# Altium schematic sheet USBDM_SWD_SER_JS16CWJ.SchDoc(USBDM_SWD_SER_JS16CWJ)
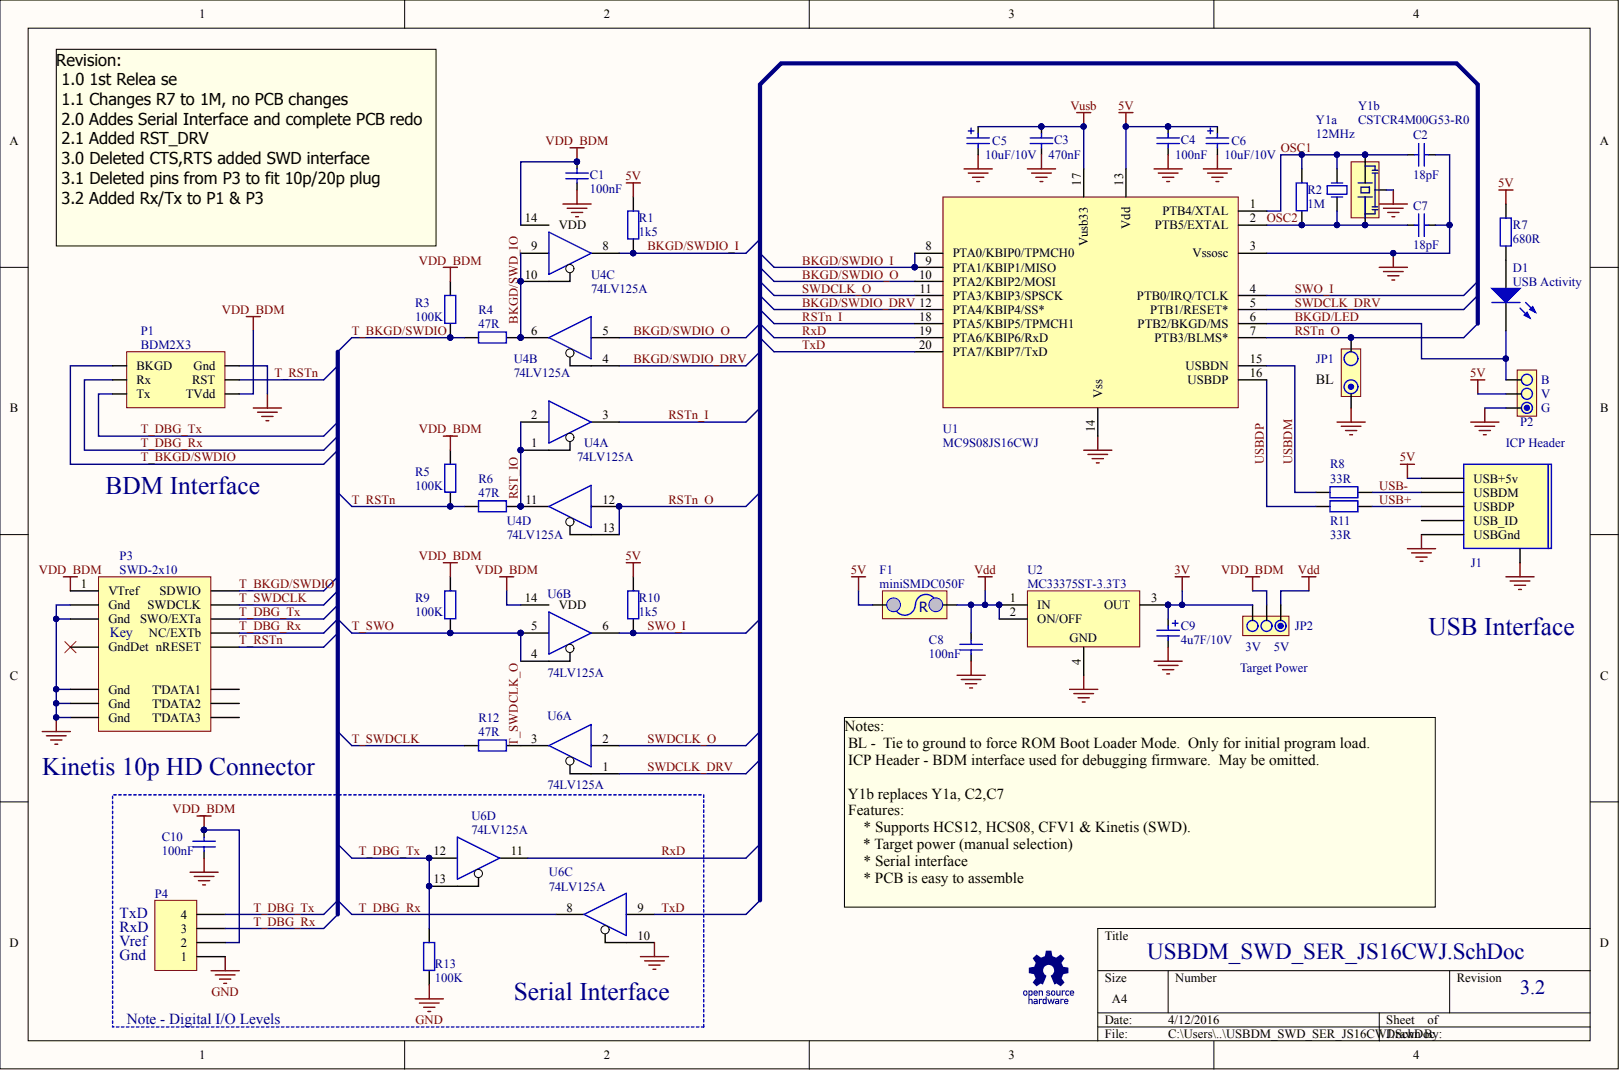
[diagram p0: USBDM_SWD_SER_JS16CWJ.SchDoc]

## Parts
C1  100nF  [CCNP2012-0805]
C2  18pF  [CCNP2012-0805]
C3  470nF  [CCNP2012-0805]
C4  100nF  [CCNP2012-0805]
C5  10uF/10V  [TC3528-1411]
C6  10uF/10V  [TC3528-1411]
C7  18pF  [CCNP2012-0805]
C8  100nF  [CCNP2012-0805]
C9  4u7F/10V  [SMT_CaseA]
C10  100nF  [CCNP2012-0805]
D1  LED Green  [LED-SMT-2]  (value not drawn)
F1  miniSMDC050F  [CR4532-1812]
J1  USB_MINI_CONNECTOR  [USB_Mini - SMT2]  (value not drawn)
JP1  PIN2X1  [HDR1X2a]  (value not drawn)
JP2  PIN3X1  [PIN1X3]  (value not drawn)  (no BOM)
Logo  OSHW Logo  [OSHW]  (value not drawn)  (no BOM)
P1  BDM2X3  [BDM]
P2  PIN3X1  [PIN1X3]  (value not drawn)  (no BOM)
P3  SWD-2x10  [SWD-2x10 - reduced]
P4  Serial  [HDR1X4]  (value not drawn)
R1  1k5  [CR2012-0805]
R2  1M  [CR2012-0805]
R3  100K  [CR2012-0805]
R4  47R  [CR2012-0805]
R5  100K  [CR2012-0805]
R6  47R  [CR2012-0805]
R7  680R  [CR2012-0805]
R8  33R  [CR2012-0805]
R9  100K  [CR2012-0805]
R10  1k5  [CR2012-0805]
R11  33R  [CR2012-0805]
R12  47R  [CR2012-0805]
R13  100K  [CR2012-0805]
U1  MC9S08JS16CWJ  [SO-G20]
U2  MC33375ST-3.3T3  [318E-04]
U4  74LV125A  [751A-03_N]  (parts A,B,C,D)
U6  74LV125A  [751A-03_N]  (parts A,B,C,D)
Y1a  12MHz  [XTAL_SMT - 5x3.2]
Y1b  CSTCR4M00G53-R0  [Resonator_Murato]

## Nets
3V: C9.1 JP2.3 U2.3(OUT)
5V: C4.2 C6.1 F1.1 J1.VBUS(USB+5v) P2.2 R1.2 R7.2 R10.2 U1.13(Vdd)
GND: C1.1 C2.1 C3.1 C4.1 C5.2 C6.2 C7.1 C8.1 C9.2 C10.1 J1.0 J1.G(USBGnd) J1.Shield JP1.1 P1.2(Gnd) P2.1 P3.3(Gnd) P3.5(Gnd) P3.15(Gnd) P3.17(Gnd) P3.19(Gnd) P4.1 R13.1 U1.3(Vssosc) U1.14(Vss) U2.4 U4.7 U6.7 U6.10 Y1b.2
VDD: C8.2 F1.2 JP2.1 U2.1(IN) U2.2(ON/OFF)
VDD_BDM: C1.2 C10.2 JP2.2 P1.6(TVdd) P3.1(VTref) P4.2 R3.1 R5.1 R9.1 U4.14(VDD) U6.14(VDD)
VUSB: C3.2 C5.1 U1.17(Vusb33)
BKGD/LED: D1.2 P2.3 U1.6(PTB2/BKGD/MS)
BKGD/SWD_IO: R4.1 U4.6 U4.9 U4.10
BKGD/SWDIO_DRV: U1.12(PTA4/KBIP4/SS*) U4.4
BKGD/SWDIO_I: R1.1 U1.8(PTA0/KBIP0/TPMCH0) U1.9(PTA1/KBIP1/MISO) U4.8
BKGD/SWDIO_O: U1.10(PTA2/KBIP2/MOSI) U4.5
OSC1: C2.2 R2.2 U1.1(PTB4/XTAL) Y1a.2 Y1b.1
OSC2: C7.2 R2.1 U1.2(PTB5/EXTAL) Y1a.1 Y1b.3
RST_IO: R6.1 U4.1 U4.2 U4.11
RSTN_I: U1.18(PTA5/KBIP5/TPMCH1) U4.3
RSTN_O: JP1.2 U1.7(PTB3/BLMS*) U4.12 U4.13
RXD: U1.19(PTA6/KBIP6/RxD) U6.11
SWDCLK_DRV: U1.5(PTB1/RESET*) U6.1
SWDCLK_O: U1.11(PTA3/KBIP3/SPSCK) U6.2
SWO_I: R10.1 U1.4(PTB0/IRQ/TCLK) U6.6
T_BKGD/SWDIO: P1.1(BKGD) P3.2(SDWIO) R3.2 R4.2
T_DBG_RX: P1.3(Rx) P3.8(NC/EXTb) P4.3 U6.8
T_DBG_TX: P1.5(Tx) P3.6(SWO/EXTa) P4.4 R13.2 U6.12 U6.13
T_RSTN: P1.4(RST) P3.10(nRESET) R5.2 R6.2
T_SWDCLK: P3.4(SWDCLK) R12.2
T_SWDCLK_O: R12.1 U6.3
T_SWO: R9.2 U6.4 U6.5
TXD: U1.20(PTA7/KBIP7/TxD) U6.9
USB+: J1.D+(USBDP) R11.1
USB-: J1.D- R8.1
USBDM: R8.2 U1.15(USBDN)
USBDP: R11.2 U1.16(USBDP)
NetD1_1: D1.1 R7.1
N00009:
N00010:

Not connected: J1 ID(USB_ID); P3 9(GndDet) 16(T'DATA1) 18(T'DATA2) 20(T'DATA3)

## Library data of the parts
C1-C4 C7 C8 C10 = Cap: Capacitor SMT; Manufacturer: Generic Components; Class: Passive; Component Kind: Standard; Library Name: MyLibrary.IntLib; Published: 08/06/2000; Signal Integrity: Cap; Simulation: CAP; SubClass: Capacitor; Publisher: Altium Hobart Technology Centre
  C1 C4 C10: Farnell PN: 8820120; Value: 100nF
  C2 C7: Farnell PN: 1414673; Value: 18pF
  C3: Farnell PN: 1288279; Value: 470nF
  C8: Farnell PN: 1186187; Value: 100nF
C5 C6 = Cap Polar: Capacitor Polarized (SMT - Case A); Manufacturer: Generic Components; Class: Passive; Component Kind: Standard; Farnell PN: 9527745; Library Name: MyLibrary.IntLib; Published: 08/06/2000; Signal Integrity: Cap Pol; Simulation: CAP; SubClass: Capacitor; Value: 10uF/10V; Publisher: Altium Hobart Technology Centre
C9 = Cap Polar: Polarized Capacitor; Manufacturer: Generic Components; Class: Passive; Component Kind: Standard; Farnell PN: 9527737; Library Name: MySchLib.schlib; Published: 08/06/2000; Signal Integrity: Cap Pol; Simulation: CAP; SubClass: Capacitor; Value: 4u7F/10V; Publisher: Altium Hobart Technology Centre
D1 = LED SMT,Green: LED (Green SMT); Manufacturer: Generic Components; Class I: Optoelectronic; Class II: LED; Component Kind: Standard; Farnell PN: 5790852; Library Name: MyLibrary.IntLib; Published: 8-Jun-2000; Revision: July-2002: Re-released for DXP Platform.; Publisher: Altium Limited
F1 = Polyswitch: Component Kind: Standard; Description: Polyswitch; Farnell PN: 1175839; Library Name: MySchLib.schlib
J1 = USB_MINI_CONNECTOR: USB Mini-B Connector; Component Kind: Standard; Farnell PN: 1363522; Library Name: MySchLib.schlib
P3 = SWD2X10-Reduced: SWD Connector 2x10-Pin; Component Kind: Standard; LatestRevisionDate: 17-Jul-2002; LatestRevisionNote: Re-released for DXP Platform.; Library Name: MyLibrary.IntLib; Signal Integrity: Connector; Publisher: Altium Limited
P4 = Header 4: Header, 4-Pin; Component Kind: Standard; LatestRevisionDate: 17-Jul-2002; LatestRevisionNote: Re-released for DXP Platform.; Library Name: Miscellaneous Connectors.IntLib; Signal Integrity: Connector; Publisher: Altium Limited
R1-R13 = Res: Resistor SMT; Manufacturer: Generic Components; Class: Passive; Component Kind: Standard; Library Name: MyLibrary.IntLib; Published: 08/06/2000; Signal Integrity: Res; Simulation: RESISTOR; SubClass: Resistor; Publisher: Altium Hobart Technology Centre
  R1 R10: Farnell PN: 1632464; Value: 1k5
  R2: Farnell PN: 9236392; Value: 1M
  R3: Farnell PN: 9332405; Value: 100K
  R4 R6 R12: Farnell PN: 1632490; Value: 47R
  R5 R9: Value: 100K
  R7: Farnell PN: 9334785; Value: 680R
  R8 R11: Farnell PN: 1631399; Value: 33R
  R13: Farnell PN: 1638463; Value: 100K
U1 = MC9S08JS16CWJ: Microcontroller with USB; Component Kind: Standard; Farnell PN: 1704517; Library Name: MyLibrary.IntLib
U2 = MC33375ST-3.3T3: Low Dropout 300mA Voltage Regulator with ON/OFF Control; Component Kind: Standard; ComponentLink1Description: Manufacturer Link; ComponentLink1URL: http://www.onsemi.com/site/products/taxonomy/0,4438,503,00.html; ComponentLink2Description: Datasheet; ComponentLink2URL: http://www.onsemi.com/pub/Collateral/MC33375-D.PDF; DatasheetVersion: Mar-2000; Farnell PN: 1150444; Library Name: ON Semi Power Mgt Voltage Regulator.IntLib; PackageDescription: SOT-223/SC-73; 4 Leads; Body 6.7 x 7.3 mm, inc. leads (LxW max); PackageReference: 318E-04; PackageVersion: Nov-1999; Published: 15-Apr-2002; Publisher: Altium Limited
U4 U6 = MC74HC125AD: Quad 3-State Non-Inverting Buffer (F1013776); Code_IPC: SO14; Code_JEDEC: MS-012-AB; Component Kind: Standard; ComponentLink1Description: Manufacturer Link; ComponentLink1URL: http://www.onsemi.com/; DatasheetDocument: Mar-2000; Farnell PN: 9592504; Library Name: ON Semi Logic Buffer Line Driver.IntLib; PackageReference: 751A-03; Published: 15-Apr-2002; Signal Integrity: MC74HC125AD; Publisher: Altium Limited
Y1a = XTAL_SMT: Crystal (Low profile SMT); Manufacturer: Generic Components; Class: Oscillator; SubClass: Crystal Oscillator; Component Kind: Standard; Farnell PN: F1368769; Library Name: MySchLib.schlib; Published: 08/06/2000; Publisher: Altium Hobart Technology Centre
Y1b = CSTCRxMxxG53: 4MHz Ceramic Resonator with caps; Component Kind: Standard; Farnell PN: 1170428; Library Name: MyLibrary.IntLib
JP1 = PIN2X1: Component Kind: Standard; Library Name: MyLibrary.IntLib
JP2 P2 = PIN3X1: Library Name: MyLibrary.IntLib
Logo = OSHW Logo: Library Name: MySchLib.schlib
P1 = BDM2X3: Component Kind: Standard; Library Name: MyLibrary.IntLib
